# Altium schematic sheet BME280.SchDoc
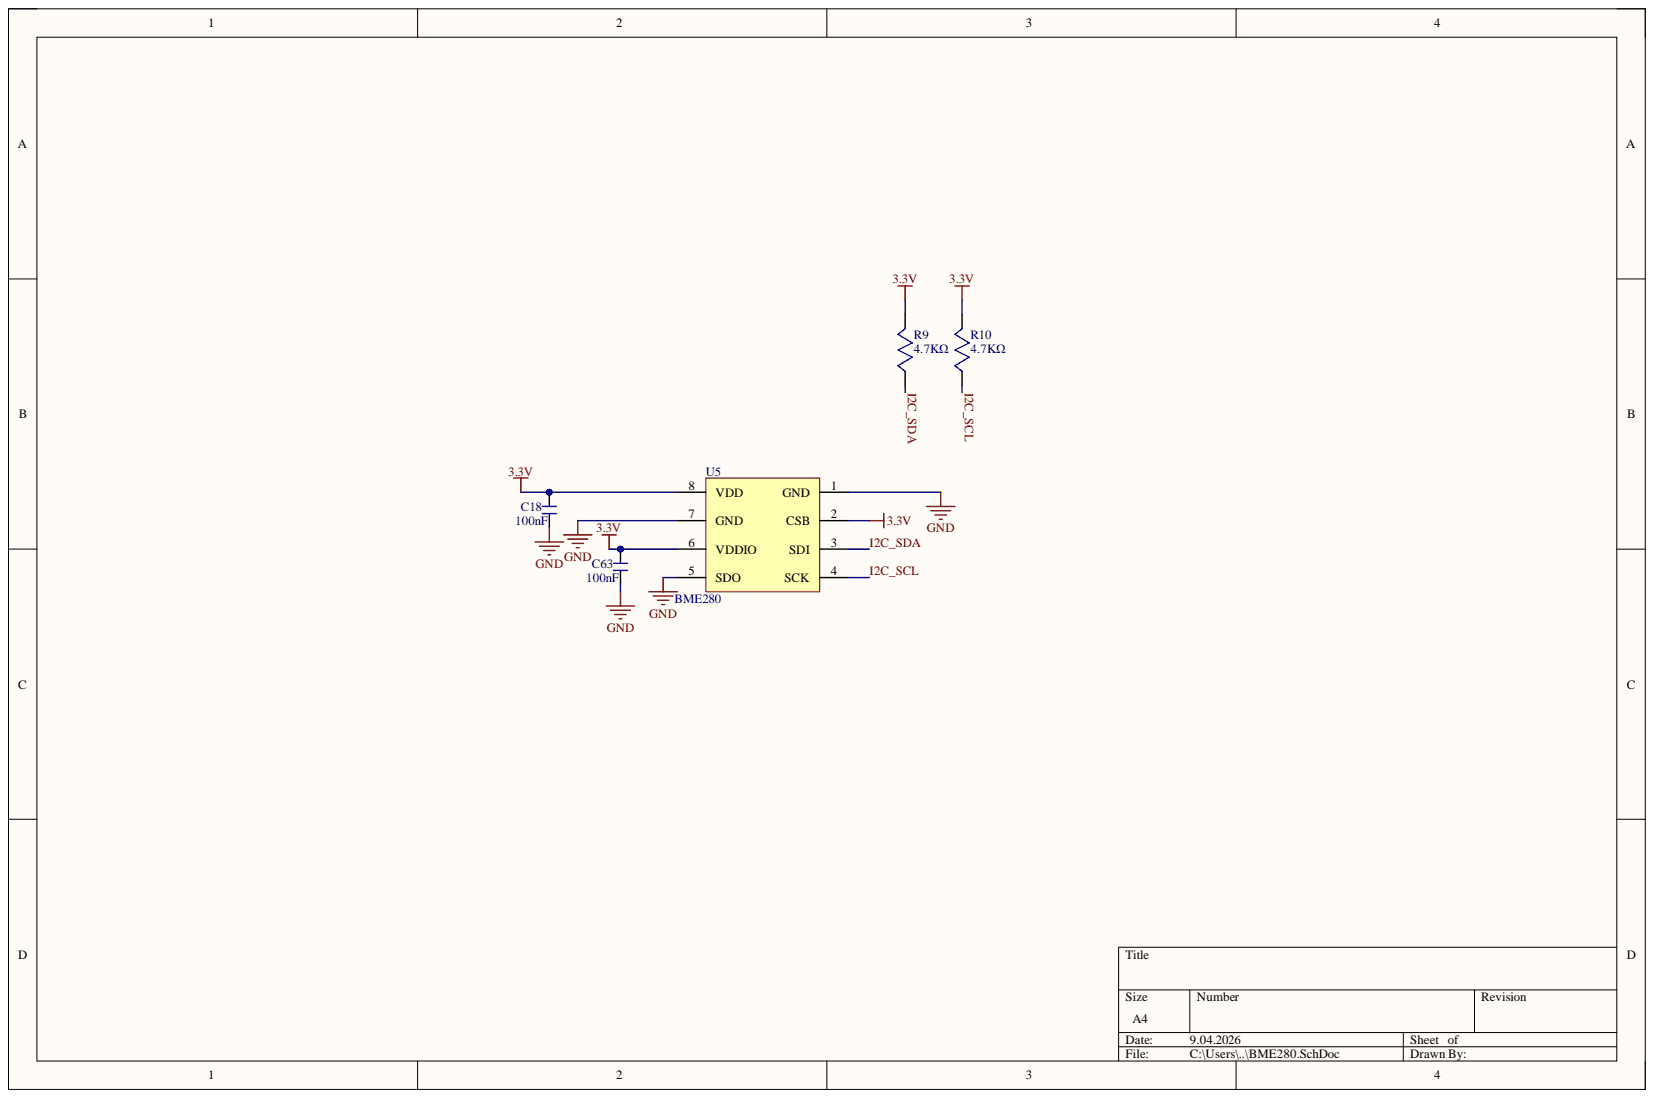
[diagram p0: BME280.SchDoc]

## Parts
C18  value 100nF  [402 capacitor - duplicate]  (hidden comment: 0402 capacitor)
C63  value 100nF  [402 capacitor - duplicate]  (hidden comment: 0402 capacitor)
R9  value 4.7KΩ  [402 RESISTOR]  (hidden comment: 0402. RESİSTOR)
R10  value 4.7KΩ  [402 RESISTOR]  (hidden comment: 0402. RESİSTOR)
U5  BME280  [BME280]

## Nets
3.3V: C18.2 C63.2 R9.2 R10.2 U5.2(CSB) U5.6(VDDIO) U5.8(VDD)
GND: C18.1 C63.1 U5.1(GND) U5.5(SDO) U5.7(GND)
I2C_SCL: R10.1 U5.4(SCK)
I2C_SDA: R9.1 U5.3(SDI)

## Library data of the parts
C18 C63 = CON SMD 402: MPN: CL05A104KA5NNNC; Component Kind: Standard; Description: CON SMD 402; Library Name: Schlib1.SchLib; Package: 0402
R9 R10 = RES SMD 0402: MPN: RMCF0402FT4K70; Component Kind: Standard; Description: RES SMD 0402; Library Name: Schlib1.SchLib; Package: 0402
U5 = BME280: SENSOR PRESSURE HUMIDITY TEMP; Component Kind: Standard; Library Name: Schlib1.SchLib; MPN: BME280
